# Altium schematic sheet 3atmel.SchDoc
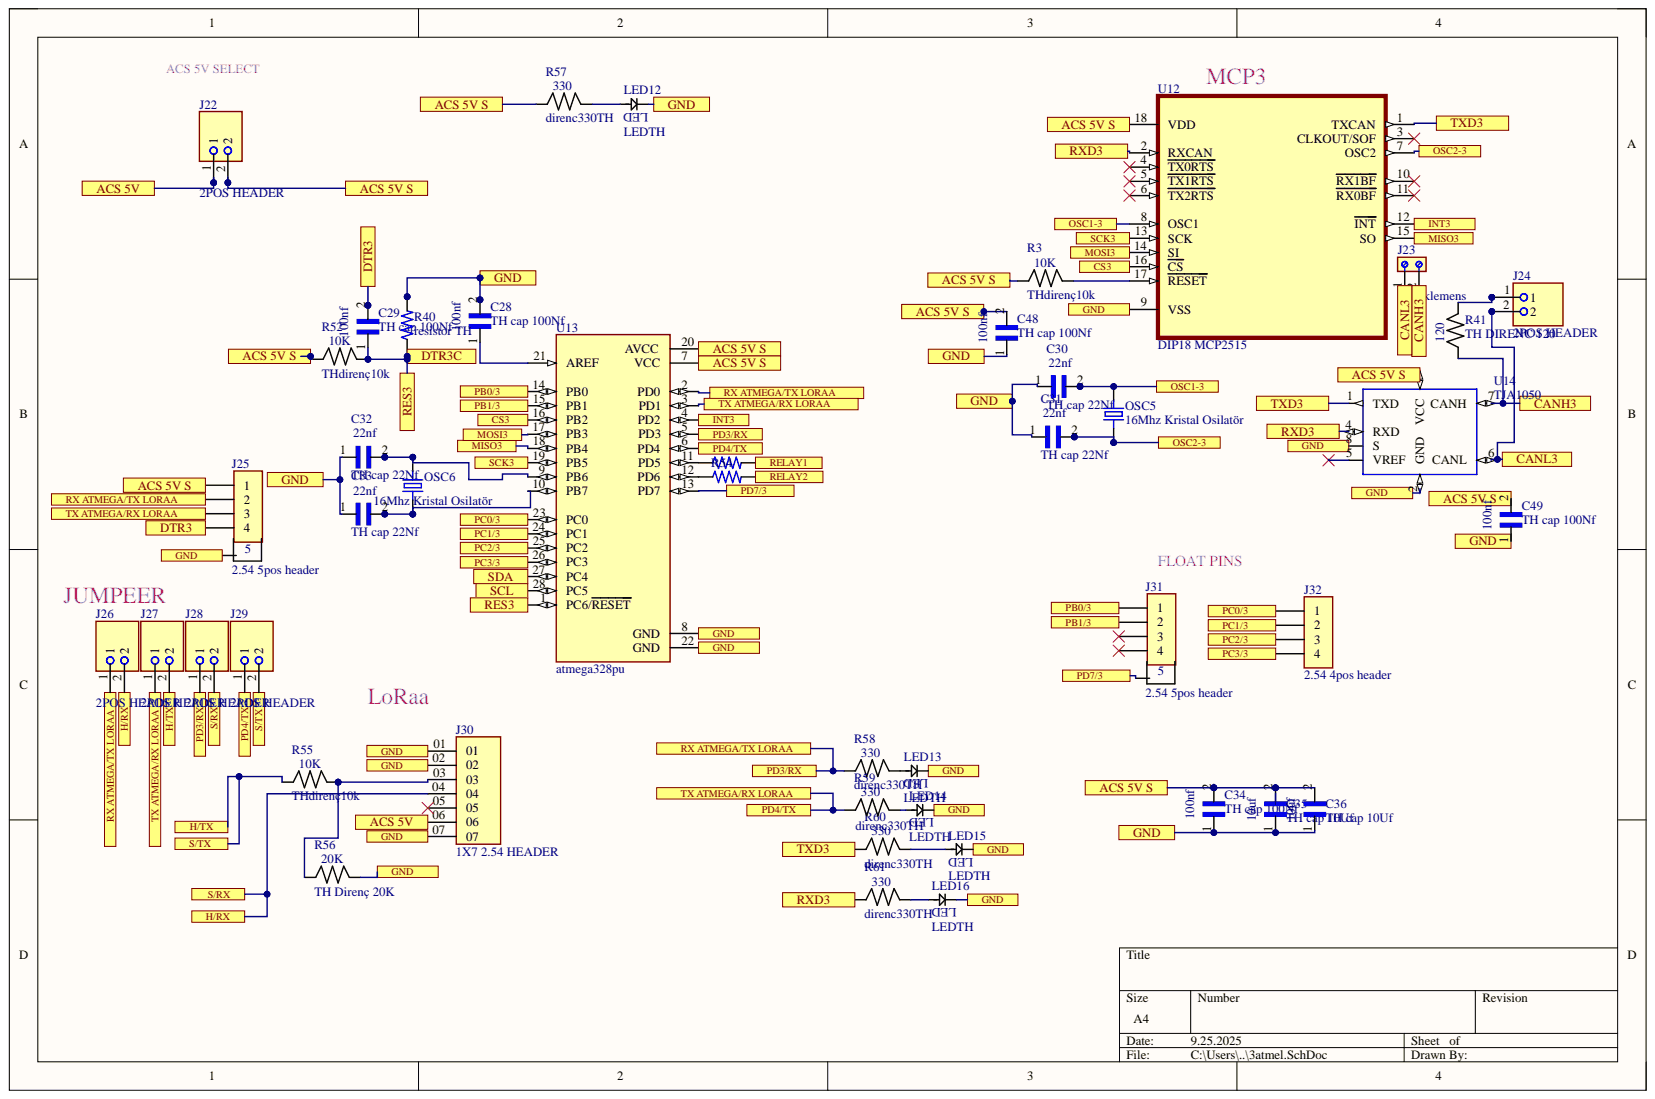
[diagram p0: 3atmel.SchDoc]

## Parts
C28  TH cap 100Nf  [Kapkucuk]
C29  TH cap 100Nf  [Kapkucuk]
C30  TH cap 22Nf  [Kapkucuk]
C31  TH cap 22Nf  [Kapkucuk]
C32  TH cap 22Nf  [Kapkucuk]
C33  TH cap 22Nf  [Kapkucuk]
C34  TH cap 100Nf  [Kapkucuk]
C35  TH cap 10Uf  [Kapkucuk]
C36  TH cap 10Uf  [Kapkucuk]
C48  TH cap 100Nf  [Kapkucuk]
C49  TH cap 100Nf  [Kapkucuk]
J22  2POS HEADER  [1X2 HEADER]
J23  5mmklemens  [5mmklemens]
J24  2POS HEADER  [1X2 HEADER]
J25  2.54 5pos header  [2.54 5pos header]
J26  2POS HEADER  [1X2 HEADER]
J27  2POS HEADER  [1X2 HEADER]
J28  2POS HEADER  [1X2 HEADER]
J29  2POS HEADER  [1X2 HEADER]
J30  1X7 2.54 HEADER  [1X7 2.54 HEADER]
J31  2.54 5pos header  [2.54 5pos header]
J32  2.54 4pos header  [2.54 4pos header]
LED12  LEDTH  [led3mm]
LED13  LEDTH  [led3mm]
LED14  LEDTH  [led3mm]
LED15  LEDTH  [led3mm]
LED16  LEDTH  [led3mm]
OSC5  16Mhz Kristal Osilatör  [16Mhz Kristal Osilatör]
OSC6  16Mhz Kristal Osilatör  [16Mhz Kristal Osilatör]
R3  THdirenç10k  [resistor TH]
R40  resistor TH  [resistor TH]
R41  TH DIRENC 120  [resistor TH]
R52  THdirenç10k  [resistor TH]
R53  resistor TH  [resistor TH]  (value not drawn)
R54  resistor TH  [resistor TH]  (value not drawn)
R55  THdirenç10k  [resistor TH]
R56  TH Direnç 20K  [resistor TH]
R57  direnc330TH  [resistor TH]
R58  direnc330TH  [resistor TH]
R59  direnc330TH  [resistor TH]
R60  direnc330TH  [resistor TH]
R61  direnc330TH  [resistor TH]
U12  DIP18 MCP2515  [DIP18 MCP2515]
U13  atmega328pu  [atmega328pu]
U14  TJA1050  [TJA1050]

## Ports
ACS 5V, ACS 5V S, CANH3, CANL3, CS3, DTR3, DTR3C, GND, H/RX, H/TX, INT3, MISO3, MOSI3, OSC1-3, OSC2-3, PB0/3, PB1/3, PC0/3, PC1/3, PC2/3, PC3/3, PD3/RX, PD4/TX, PD7/3, RELAY1, RELAY2, RES3, RX ATMEGA/TX LORAA, RXD3, S/RX, S/TX, SCK3, SCL, SDA, TX ATMEGA/RX LORAA, TXD3

## Nets
ACS 5V: J22.1 J30.06 ; port ACS 5V
ACS 5V S: C34.2 C35.2 C36.2 C48.2 C49.2 J22.2 J25.1 R3.0 R52.0 R57.0 U12.18(VDD) U13.7(VCC) U13.20(AVCC) U14.3(VCC) ; port ACS 5V S
CANH3: J23.2 R41.0 U14.7(CANH) ; port CANH3
CANL3: J23.1 J24.2 U14.6(CANL) ; port CANL3
CS3: U12.16(CS) U13.16(PB2) ; port CS3
DTR3: C29.2 J25.4 ; port DTR3
DTR3C: C29.1 R40.0 R52.1 U13.1(PC6/RESET) ; port DTR3C, port RES3
GND: C28.2 C30.1 C31.1 C32.1 C33.1 C34.1 C35.1 C36.1 C48.1 C49.1 J25.5 J30.01 J30.02 J30.07 LED12.1 LED13.1 LED14.1 LED15.1 LED16.1 R40.1 R56.1 U12.9(VSS) U13.8(GND) U13.22(GND) U14.2(GND) U14.8(S) ; port GND
H/RX: J26.2 J28.2 J30.04 ; port H/RX, port S/RX
H/TX: J27.2 J29.2 R55.0 ; port H/TX, port S/TX
INT3: U12.12(INT) U13.4(PD2) ; port INT3
MISO3: U12.15(SO) U13.18(PB4) ; port MISO3
MOSI3: U12.14(SI) U13.17(PB3) ; port MOSI3
OSC1-3: C30.2 OSC5.2 U12.8(OSC1) ; port OSC1-3
OSC2-3: C31.2 OSC5.1 U12.7(OSC2) ; port OSC2-3
PB0/3: J31.1 U13.14(PB0) ; port PB0/3
PB1/3: J31.2 U13.15(PB1) ; port PB1/3
PC0/3: J32.1 U13.23(PC0) ; port PC0/3
PC1/3: J32.2 U13.24(PC1) ; port PC1/3
PC2/3: J32.3 U13.25(PC2) ; port PC2/3
PC3/3: J32.4 U13.26(PC3) ; port PC3/3
PD3/RX: J25.2 J26.1 J28.1 R58.0 U13.2(PD0) U13.5(PD3) ; port PD3/RX, port RX ATMEGA/TX LORAA
PD4/TX: J25.3 J27.1 J29.1 R59.0 U13.3(PD1) U13.6(PD4) ; port PD4/TX, port TX ATMEGA/RX LORAA
PD7/3: J31.5 U13.13(PD7) ; port PD7/3
RELAY1: R53.1 ; port RELAY1
RELAY2: R54.1 ; port RELAY2
RXD3: R61.0 U12.2(RXCAN) U14.4(RXD) ; port RXD3
SCK3: U12.13(SCK) U13.19(PB5) ; port SCK3
SCL: U13.28(PC5) ; port SCL
SDA: U13.27(PC4) ; port SDA
TXD3: R60.0 U12.1(TXCAN) U14.1(TXD) ; port TXD3
NetC28_1: C28.1 U13.21(AREF)
NetC32_2: C32.2 OSC6.2 U13.9(PB6)
NetC33_2: C33.2 OSC6.1 U13.10(PB7)
NetJ24_1: J24.1 R41.1
NetJ30_03: J30.03 R55.1 R56.0
NetLED12_0: LED12.0 R57.1
NetLED13_0: LED13.0 R58.1
NetLED14_0: LED14.0 R59.1
NetLED15_0: LED15.0 R60.1
NetLED16_0: LED16.0 R61.1
NetR3_1: R3.1 U12.17(RESET)
NetR53_0: R53.0 U13.11(PD5)
NetR54_0: R54.0 U13.12(PD6)

Not connected: J30 05; J31 3 4; U12 3(CLKOUT/SOF) 4(TX0RTS) 5(TX1RTS) 6(TX2RTS) 10(RX1BF) 11(RX0BF); U14 5(VREF)

## Library data of the parts
C28 C29 C34 C48 C49 = TH cap 100Nf: Component Kind: Standard; Library Name: Copy of Parts.SchLib
C30-C33 = TH cap 22nf: Component Kind: Standard; Library Name: Copy of Parts.SchLib
C35 C36 = TH cap 10Uf: Component Kind: Standard; Library Name: Copy of Parts.SchLib
J22 J24 J26-J29 = 2POS HEADER: Component Kind: Standard; Library Name: Copy of Parts.SchLib
J23 = 5mmklemens: Component Kind: Standard; Library Name: Copy of Parts.SchLib
J25 J31 = 2.54 5pos header: Component Kind: Standard; Library Name: Copy of Parts.SchLib
J30 = 1X7 2.54 HEADER: Component Kind: Standard; Library Name: Copy of Parts.SchLib
J32 = 2.54 4pos header: Component Kind: Standard; Library Name: Copy of Parts.SchLib
LED12-LED16 = LEDTH: Component Kind: Standard; Library Name: Copy of Parts.SchLib
OSC5 OSC6 = 16Mhz Kristal Osilatör: Component Kind: Standard; Library Name: Copy of Parts.SchLib
R3 R52 R55 = THdirenç10k: Component Kind: Standard; Library Name: Copy of Parts.SchLib
R40 R53 R54 = resistor TH: Component Kind: Standard; Library Name: Copy of Parts.SchLib
R41 = TH DIRENC 120: Component Kind: Standard; Library Name: Copy of Parts.SchLib
R56 = TH Direnç 20K: Component Kind: Standard; Library Name: Copy of Parts.SchLib
R57-R61 = direnc330TH: Component Kind: Standard; Library Name: Copy of Parts.SchLib
U12 = DIP18 MCP2515: Component Kind: Standard; Library Name: Copy of Parts.SchLib
U13 = atmega328pu: Component Kind: Standard; Library Name: Copy of Parts.SchLib
U14 = TJA1050: Component Kind: Standard; Library Name: Copy of Parts.SchLib
